# Altium schematic sheet Main.SchDoc(Main)
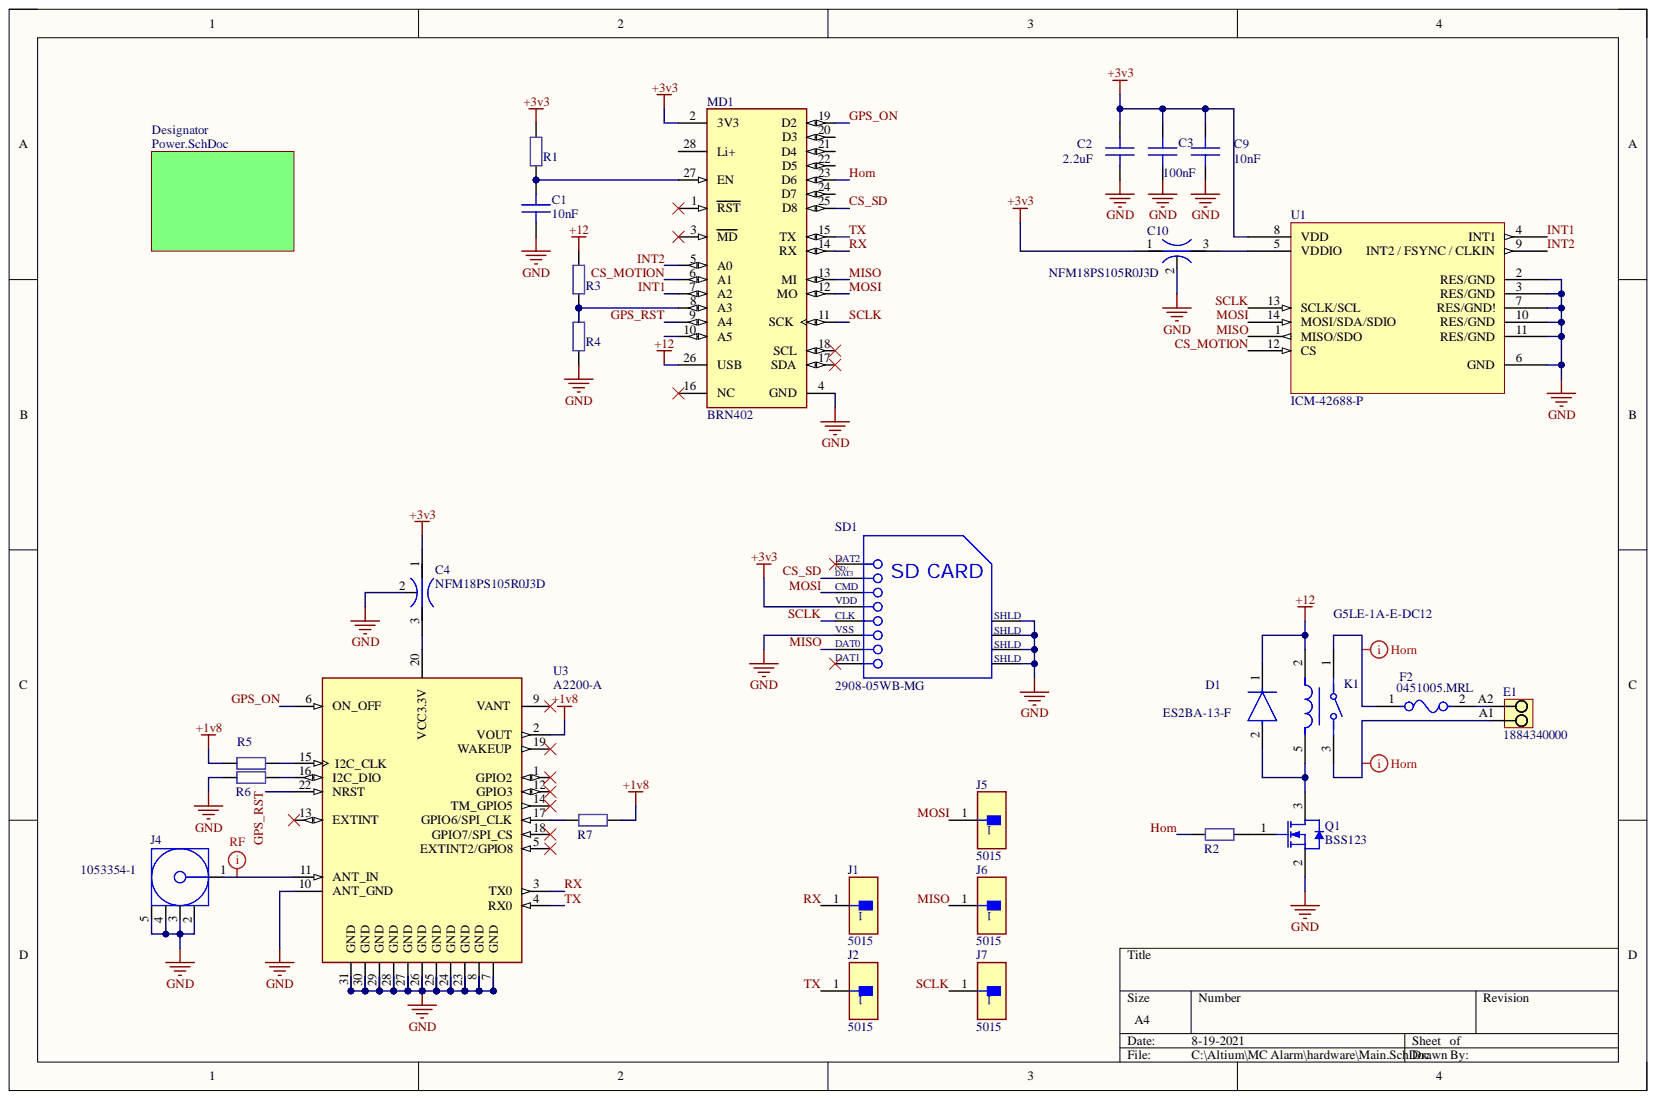
[diagram p0: Main.SchDoc]

## Parts
C1  value 10nF  [C0603 (1608)]  (hidden comment: Cap SMD)
C2  value 2.2uF  [C0603 (1608)]  (hidden comment: Cap SMD)
C3  value 100nF  [C0603 (1608)]  (hidden comment: Cap SMD)
C4  NFM18PS105R0J3D  [FP-NFM18-0_1-e0_05_0_35-MFG]
C9  value 10nF  [C0603 (1608)]  (hidden comment: Cap SMD)
C10  NFM18PS105R0J3D  [FP-NFM18-0_1-e0_05_0_35-MFG]
D1  ES2BA-13-F  [FP-SMA-MFG]
E1  1884340000  [LSF_SMT_5_00_135_02]
F2  0451005.MRL  [FP-0451-MFG]
J1  5015  [FP-5015-MFG]
J2  5015  [FP-5015-MFG]
J4  1053354-1  [TECO-1053354-1_V]
J5  5015  [FP-5015-MFG]
J6  5015  [FP-5015-MFG]
J7  5015  [FP-5015-MFG]
K1  G5LE-1A-E-DC12  [FP-G5LE-1A-E-DC12-MFG]
MD1  BRN402  [FP-BRN402-0]
Q1  BSS123  [FAIR-SOT23-3_V]
R1  Res  [R0603 (1608)]  (value not drawn)
R2  Res  [R0603 (1608)]  (value not drawn)
R3  Res  [R0603 (1608)]  (value not drawn)
R4  Res  [R0603 (1608)]  (value not drawn)
R5  Res  [R0603 (1608)]  (value not drawn)
R6  Res  [R0603 (1608)]  (value not drawn)
R7  Res  [R0603 (1608)]  (value not drawn)
SD1  2908-05WB-MG  [3M-2908-05WB-MG_V]
U1  ICM-42688-P  [ICM-42688-P]
U3  A2200-A  [XCVR_A2200-A]

## Nets
+1v8: R5.2 R7.1 U3.2(VOUT)
+3v3: C2.2 C3.2 C4.1 C9.2 C10.1 MD1.2(3V3) R1.2 SD1.4 U1.8(VDD)
+12: D1.1 K1.2 MD1.26(USB) R3.2
GND: C1.1 C2.1 C3.1 C4.2 C9.1 C10.2 J4.2 J4.3 J4.4 J4.5 MD1.4(GND) Q1.2 R4.1 R6.2 SD1.6 SD1.9 SD1.10 SD1.11 SD1.12 U1.2(RES/GND) U1.3(RES/GND) U1.6(GND) U1.7(RES/GND!) U1.10(RES/GND) U1.11(RES/GND) U3.7(GND) U3.8(GND) U3.10(ANT_GND) U3.23(GND) U3.24(GND) U3.25(GND) U3.26(GND) U3.27(GND) U3.28(GND) U3.29(GND) U3.30(GND) U3.31(GND)
CS_MOTION: MD1.6(A1) U1.12(CS)
CS_SD: MD1.25(D8) SD1.2
GPS_ON: MD1.19(D2) U3.6(ON_OFF)
GPS_RST: MD1.9(A4) U3.22(NRST)
Horn: MD1.23(D6) R2.2
INT1: MD1.7(A2) U1.4(INT1)
INT2: MD1.5(A0) U1.9
MISO: J6.1 MD1.13(MI) SD1.7 U1.1(MISO/SDO)
MOSI: J5.1 MD1.12(MO) SD1.3 U1.14(MOSI/SDA/SDIO)
RX: J1.1 MD1.14(RX) U3.3(TX0)
SCLK: J7.1 MD1.11(SCK) SD1.5 U1.13(SCLK/SCL)
TX: J2.1 MD1.15(TX) U3.4(RX0)
NetC1_2: C1.2 MD1.27(EN) R1.1
NetC4_3: C4.3 U3.20(VCC3.3V)
NetC10_3: C10.3 U1.5(VDDIO)
NetD1_2: D1.2 K1.5 Q1.3
NetE1_A1: E1.A1 K1.3
NetE1_A2: E1.A2 F2.2
NetF2_1: F2.1 K1.1
NetJ4_1: J4.1 U3.11(ANT_IN)
NetMD1_8: MD1.8(A3) R3.1 R4.2
NetQ1_1: Q1.1 R2.1
NetR5_1: R5.1 U3.15(I2C_CLK)
NetR6_1: R6.1 U3.16(I2C_DIO)
NetR7_2: R7.2 U3.17(GPIO6/SPI_CLK)

Not connected: MD1 1(RST) 3(MD) 10(A5) 16(NC) 17(SDA) 18(SCL) 20(D3) 21(D4) 22(D5) 24(D7) 28(Li+); SD1 1(SD_CARD) 8; U3 1(GPIO2) 5(EXTINT2/GPIO8) 9(VANT) 12(GPIO3) 13(EXTINT) 14(TM_GPIO5) 18(GPIO7/SPI_CS) 19(WAKEUP)

## Library data of the parts
C4 C10 = CMP-2000-06844-3: Large Current 3 Terminals Low ESL Chip Multilayer Ceramic Capacitors for General Purpose, 0603, 1uF, 20%, 6.3V; Current: 2A; DC Resistance (DCR): 30mR; Insulation Resistance: 500MR; Capacitance: 1uF; Case Code (Imperial): 0603; Case Code (Metric): 1608; Case/Package: 0603; Component Kind: Standard; Current Rating: 2A; Depth: 0.8mm; Height: 0.6mm; Lead Free: Lead Free; Length: 1.6mm; Library Name: Altium Content Vault; Max Current Rating: 2A; Max Operating Temperature: 105°C; Max Voltage Rating (DC): 6.3V; Min Operating Temperature: -55°C; Mount: Surface Mount; Package Quantity: 1; Packaging: Tape and Reel; Radiation Hardening: No; REACH SVHC: No SVHC; Termination: Flat; Tolerance: 20%; Voltage: 6.3V; Voltage Rating: 6.3V; Voltage Rating (DC): 6.3V; Weight: 7.1E-05oz; Width: 0.8mm
D1 = CMP-12791-000037-1: DIODE GEN PURP 100V 2A SMA; Manufacturer: Diodes Inc.; Capacitance: 25pF; Max Junction Temp: +150°C; Max Surge Forward Current: 50A; Peak Reverse Current: 5uA; Standoff Height: 0.05mm; Value: 25pF; Automotive: No; Category: Diode; Component Kind: Standard; ComponentLink2Description: Datasheet; ComponentLink2URL: https://www.diodes.com/assets/Datasheets/ds14002.pdf; Device Class L1: Discrete Semiconductors; Device Class L2: Diodes; Device Class L3: Rectifier Diodes; Digikey Description: DIODE GEN PURP 100V 2A SMA; Footprint Url: https://www.diodes.com/assets/Package-Files/SMA.pdf; Forward Current: 2A; Height: 2.4mm; Lead Free: Yes; Library Name: Altium Content Vault; Manufacturer Part Number: ES2BA-13-F; Max Repetitive Reverse Voltage: 100V; Mouser Description: ES2BA Series 100 V 2 A Surface Mount Super-Fast Rectifier - DO-214AC; Octopart: https://octopart.com/es2ba-13-f-diodes+inc.-14402013; Package: SMA; Reverse Voltage: 100V; RoHS: TRUE; Temperature: -55°C to +150°C; Temperature Range High: +150°C; Temperature Range Low: -55°C
E1 = CMP-1559-02276-1: OMNIMATE Signal - series LSF; Manufacturer: Weidmueller; Contact_material: Cu-Leg; Contact_surface: tinned; Insulating_material: LCP GF; Mounting_onto_the_PCB: Reflow solder connection; No_of_poles: 2; Pitch_inch: 0,197 inch; Pitch_mm: 5 mm; Rated_current_min_no_of_poles_TU_20_deg_C: 19 A; Rated_current_use_group_B_UL_1059: 12 A; Rated_voltage_for_surge_voltage_class_pollution_degree_II_2: 500 V; Rated_voltage_use_group_B_UL_1059: 300 V; Short_text_for_material: LSF-SMT 5.00/02/135 3.5SN BK TU; Side_termination_characteristic: closed side; Solder_pin_length_mm: 3,5 mm; Solid_max_H05_07_V_U: 1,5 mm²; Solid_min_H05_07_V_U: 0,2 mm²; UL_94_flammability_rating: V-0; Wire_cross_section_AWG_max_UL_1059: AWG 16; Wire_cross_section_AWG_min_UL_1059: AWG 24; Colour: Black; Component Kind: Standard; ComponentLink1Description: Manufacturer URL; ComponentLink1URL: http://www.weidmueller.com/; ComponentLink2Description: URL Link1; ComponentLink2URL: http://catalog.weidmueller.com/catalog/Start.do?localeId=en&ObjectID=1884340000; Library Name: Altium Content Vault; PackageReference: LSF_SMT_5_00_135_02; Packaging: Tube; PartNumber: 1884340000; Qty: 60 PCS; Revision: V1
F2 = CMP-2000-05653-2: FUSE BRD MNT 5A 125VAC/VDC 2SMD; Manufacturer: Littelfuse Inc; Fuse Blow Speed: Fast; Fuse I2T: 5.566A2Sec; Fuse Interrupt Ac Current: 50A; Max Fuse Ac Voltage: 125V; Resistance: 0.0125Ω; Value: 0.0125Ω; Automotive: No; Category: Fuse; Component Kind: Standard; ComponentLink2Description: Datasheet; ComponentLink2URL: https://www.littelfuse.com/~/media/electronics/datasheets/fuses/littelfuse_fuse_451_453_datasheet.pdf.pdf; Device Class L1: Circuit Protection; Device Class L2: Fuses; Device Class L3: unset; Digikey Description: FUSE BRD MNT 5A 125VAC/VDC 2SMD; Fuse Current: 5A; Fuse Interrupt Dc Current: 50A; Height: 2.94mm; Lead Free: Yes; Library Name: Altium Content Vault; Manufacturer Part Number: 0451005.MRL; Max Fuse Dc Voltage: 125V; Mouser Description: Surface Mount Fuses 125V 5A; Octopart: https://octopart.com/0451005.mrl-littelfuse-566389; Package: 0451; RoHS: TRUE; Temperature: -55°C to +125°C; Temperature Range High: +125°C; Temperature Range Low: -55°C; Voltage Rating: 125V
J1 J2 J5-J7 = CMP-2000-05183-2: PC TEST POINT MINIATURE; Manufacturer: keystone; Conn Orientation: Straight; Number Of Contacts: 1; Automotive: No; Category: Conn; Component Kind: Standard; ComponentLink2Description: Datasheet; ComponentLink2URL: http://www.keyelco.com/product-pdf.cfm?p=1353; Contact Material: Silver; Device Class L1: Connectors; Device Class L2: unset; Device Class L3: unset; Digikey Description: PC TEST POINT MINIATURE; Height: 1.65mm; Lead Free: Yes; Library Name: Altium Content Vault; Manufacturer Part Number: 5015; Octopart: https://octopart.com/5015-keystone-3822; Package: 5015; RoHS: TRUE
J4 = CMP-2000-05830-1: Female Coaxial Connector, Pitch 2.55 mm, Height 9.5 mm, Tail Length 3.9 mm, RoHS, Bulk; Manufacturer: TE Connectivity; 3D Model: 1053354-1.pdf; Connector Style: SMA; Connector Type: Jack,FemaleSockets; Contact Termination: Solder; Impedance: 50Ohm; Other Names: 2062000000  A30046; Category: Connectors,Interconnects; Component Kind: Standard; Datasheet URL: http://www.te.com/commerce/DocumentDelivery/DDEController?Action=srchrtrv&DocNm=1053354&DocType=Customer+Drawing&DocLang=English; Datasheet Version: Rev. B, 05/2011; Dynamic Catalog: SMA; Family: CoaxialConnectors(RF); Fastening Type: Threaded; Library Name: Altium Content Vault; Manufacturer URL: http://www.te.com/; Mounting Technology: ThroughHole; Mounting Type: ThroughHole; Package Description: Female Coaxial Connector, Pitch 2.55 mm, Height 9.5 mm, Tail Length 3.9 mm; Package Reference: 1053354-1; Packaging: Bulk; RoHS Information: 1053354-1StatementofCompliance; Series: SMA; Standard Package: 125
K1 = CMP-08822-000128-1: RELAY GEN PURPOSE SPST 16A 12V; Coil Current: 33.3mA; Coil Power: 400mW; Coil Resistance: 360R; Coil Type: Non-Latching; Max Voltage Rating (AC): 250V; Operate Time: 10ms; Relay Type: General Purpose; Release Time: 5ms; Coil Voltage (DC): 12V; Component Kind: Standard; Contact Current Rating: 16A; Contact Material: Tin; Depth: 16.5mm; Height: 19mm; Lead Free: Lead Free; Length: 22.5mm; Library Name: Altium Content Vault; Max Current Rating: 16A; Max Operating Temperature: 85°C; Min Operating Temperature: -40°C; Mount: Through Hole; Number of Pins: 4; Package Quantity: 100; Packaging: Tray; Power Consumption: 400mW; RoHS Compliant: Yes; Series: G5LE; Termination: Solder; Throw Configuration: SPST; Voltage Rating (AC): 250V; Weight: 12g; Width: 16.5mm
MD1 = CMP-201502-000001-1: BORON LTE; Manufacturer: Particle; Bandwidth: 2302MHz; Data Rate: 2000kbits/s; Interface: I2C,SPI,UART,USB; Max Frequency: 3000MHz; Max Supply Voltage: 4.4V; Min Supply Voltage: 3V; Nominal Supply Current: 70-100000uA; Number Of Adc Channels: 6; Number Of Gpio: 20; Automotive: No; Category: UNK; Component Kind: Standard; ComponentLink2Description: Datasheet; ComponentLink2URL: https://docs.particle.io/assets/pdfs/datasheets/boron-datasheet.pdf; Device Class L1: Integrated Circuits (ICs); Device Class L2: RF Semiconductors and Devices; Device Class L3: Transceivers; Digikey Description: BORON LTE; Lead Free: Yes; Library Name: Altium Content Vault; Manufacturer Part Number: BRN402; Octopart: https://octopart.com/brn402-particle-96116406; Package: BRN402; RoHS: TRUE; Temperature: -20°C to +60°C; Temperature Range High: +60°C; Temperature Range Low: -20°C
Q1 = CMP-2000-04934-1: N-Channel Logic Level Enhancement Mode Field Effect Transistor, 1.7 V, -55 to 150 degC, 3-Pin SOT-23, RoHS, Tape and Reel; Manufacturer: Fairchild; Channel Type: N; Maximum Continuous Drain Current: 0.17A; Maximum Drain Source Voltage: 100V; Maximum Gate Source Voltage: ±20V; Package Version: Rev. 12, 03/2016; Rad Hard: No; RDS-on: 6000@10VmOhm; Typical Fall Time: 2.4ns; Typical Rise Time: 9ns; Typical Turn-Off Delay Time: 17ns; Typical Turn-On Delay Time: 1.7ns; Category: MOSFET; Channel Mode: Enhancement; Component Kind: Standard; Datasheet URL: https://www.fairchildsemi.com/datasheets/BS/BSS123.pdf; Datasheet Version: Jun-03; Library Name: Altium Content Vault; Manufacturer URL: https://www.fairchildsemi.com/; Mounting: SurfaceMount; Operating Temperature: -55to150degC; Package: 3SOT-23; Package Description: 3-Pin Small Outline Transistor, Body 2.92 x 1.325 mm, Pitch 0.95 mm; Package Reference: SOT23-3; Package URL: http://www.fairchildsemi.com/dwg/MA/MA03D.pdf; Packaging: Tape&Reel
R1-R7 = Resistor EU: Component Kind: Standard; Library Name: Moto Symbol Lib.SchLib
SD1 = CMP-2000-05030-1: Card Connector Micro-SD, 500 VAC, 100 mOhm Min, 0.5 A, RoHS, Tape and Reel; Manufacturer: 3M; Card Type: SecureDigital-microSD™; Connector Type: ConnectorandEjector; Featured Product: 3MCardConnectormicroSD; Insertion, Removal Method: PushIn,PushOut; Number of Positions: 10(8+2); Online Catalog: 2900Series; Other Names: 3M5607CT; Other Related Documents: SDCardDateCodeDecipher; PCN Obsolescence/ EOL: 2908Series30/Jun/2010; Catalog Drawings: 2908-05WB-MG; Category: Connectors,Interconnects; Component Kind: Standard; Contact Finish: Gold; Contact Finish Thickness: 15µin(0.38µm); Datasheet URL: http://datasheet.octopart.com/2908-05WB-MG-3M-datasheet-6398107.pdf; Datasheet Version: Oct-06; Ejector Side: -; Family: MemoryConnectors-PCCardSockets; Features: Switch; Height Above Board: 0.073"(1.85mm); Library Name: Altium Content Vault; Manufacturer URL: http://www.3m.com/; Mounting Feature: Normal,Standard-Top; Mounting Type: SurfaceMount,RightAngle; Package Description: 8-Pin Surface Mount Device, Body 15.2 x 14 mm, Pitch 1.1 mm; Package Reference: 2908-05WB-MG; Packaging: CutTape(CT); Series: 2900; Standard Package: 1
U3 = A2200-A: SiRFstarIVâ„¢ RF Receiver GPS 1.575GHz -163dBm 115.2kbps Module; MF: Maestro Wireless; Availability: Unavailable; Component Kind: Standard; MP: A2200-A; Package: Module Maestro Wireless; Price: None; SnapEDA_Link: https://www.snapeda.com/parts/A2200-A/Maestro%20Wireless%20Solutions/view-part/51723/?ref=snap; Library Name: Moto Symbol Lib.SchLib
C1-C3 C9 = Cap SMD: Component Kind: Standard; Library Name: Moto Symbol Lib.SchLib
U1 = ICM-42688-P: Component Kind: Standard; Library Name: Moto Symbol Lib.SchLib
